# Altium schematic sheet ESSICC-CPU-PTC-DA.SchDoc(ESSICC-CPU-PTC-DA)
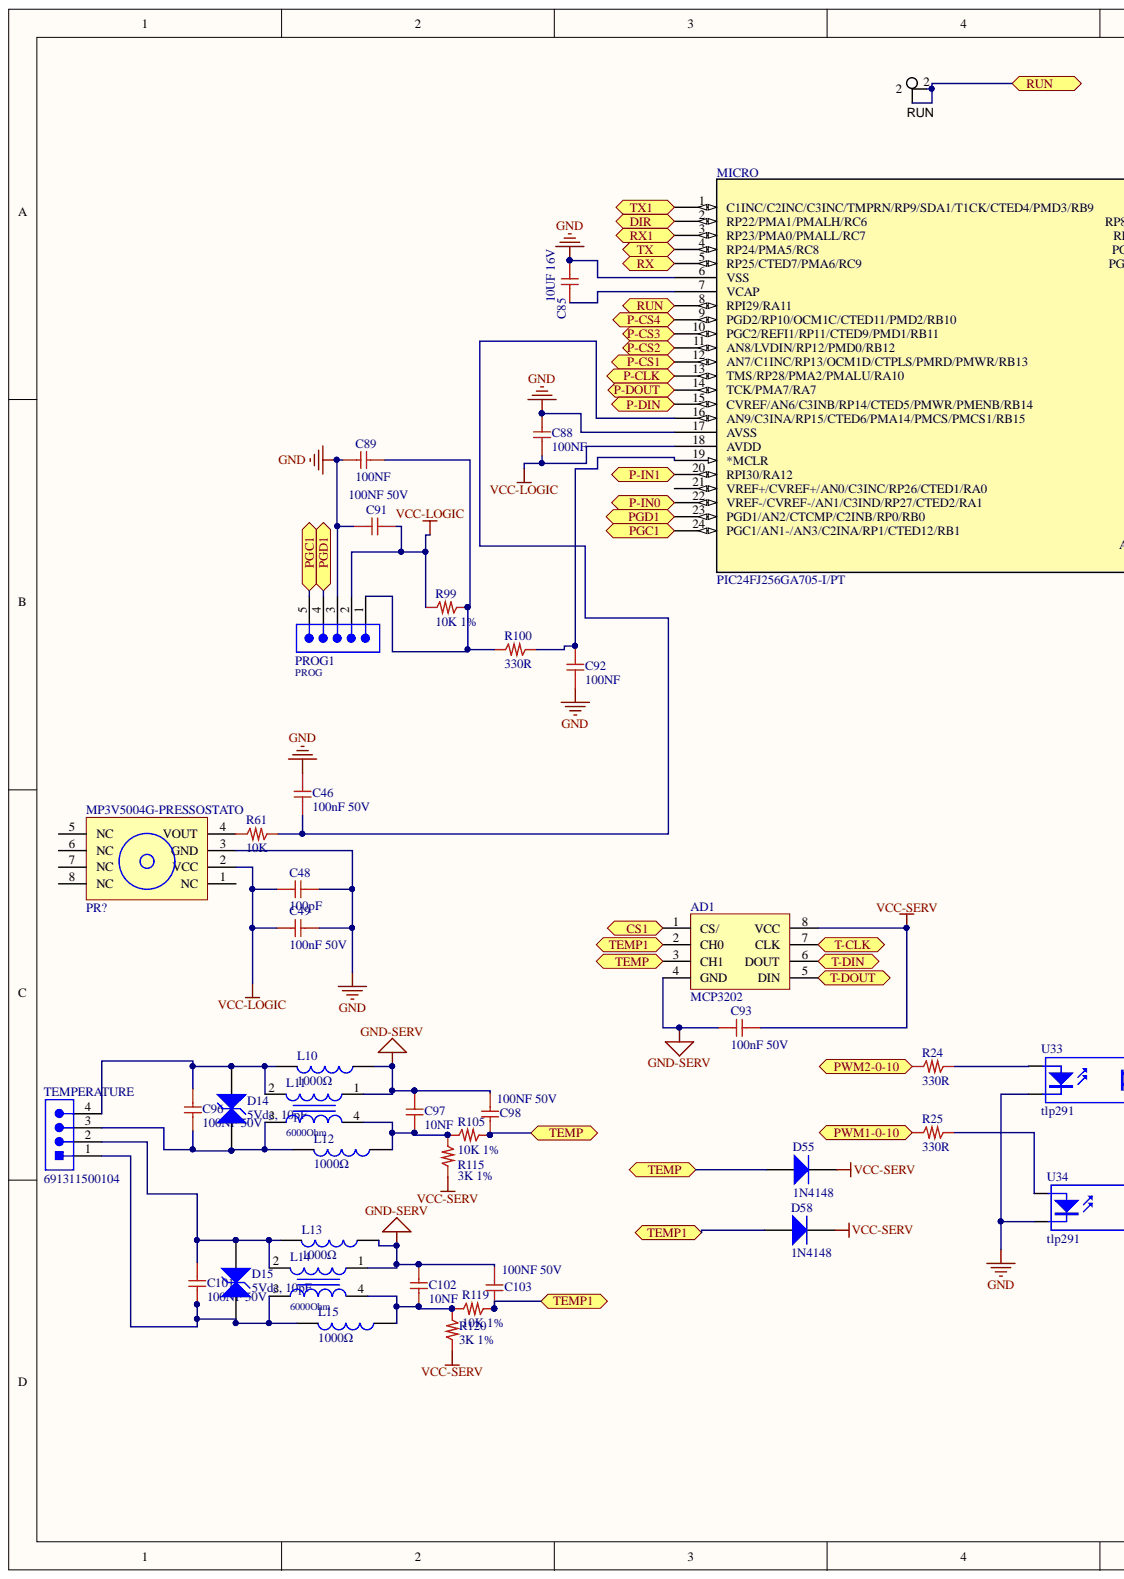
[diagram p0: ESSICC-CPU-PTC-DA.SchDoc, left]
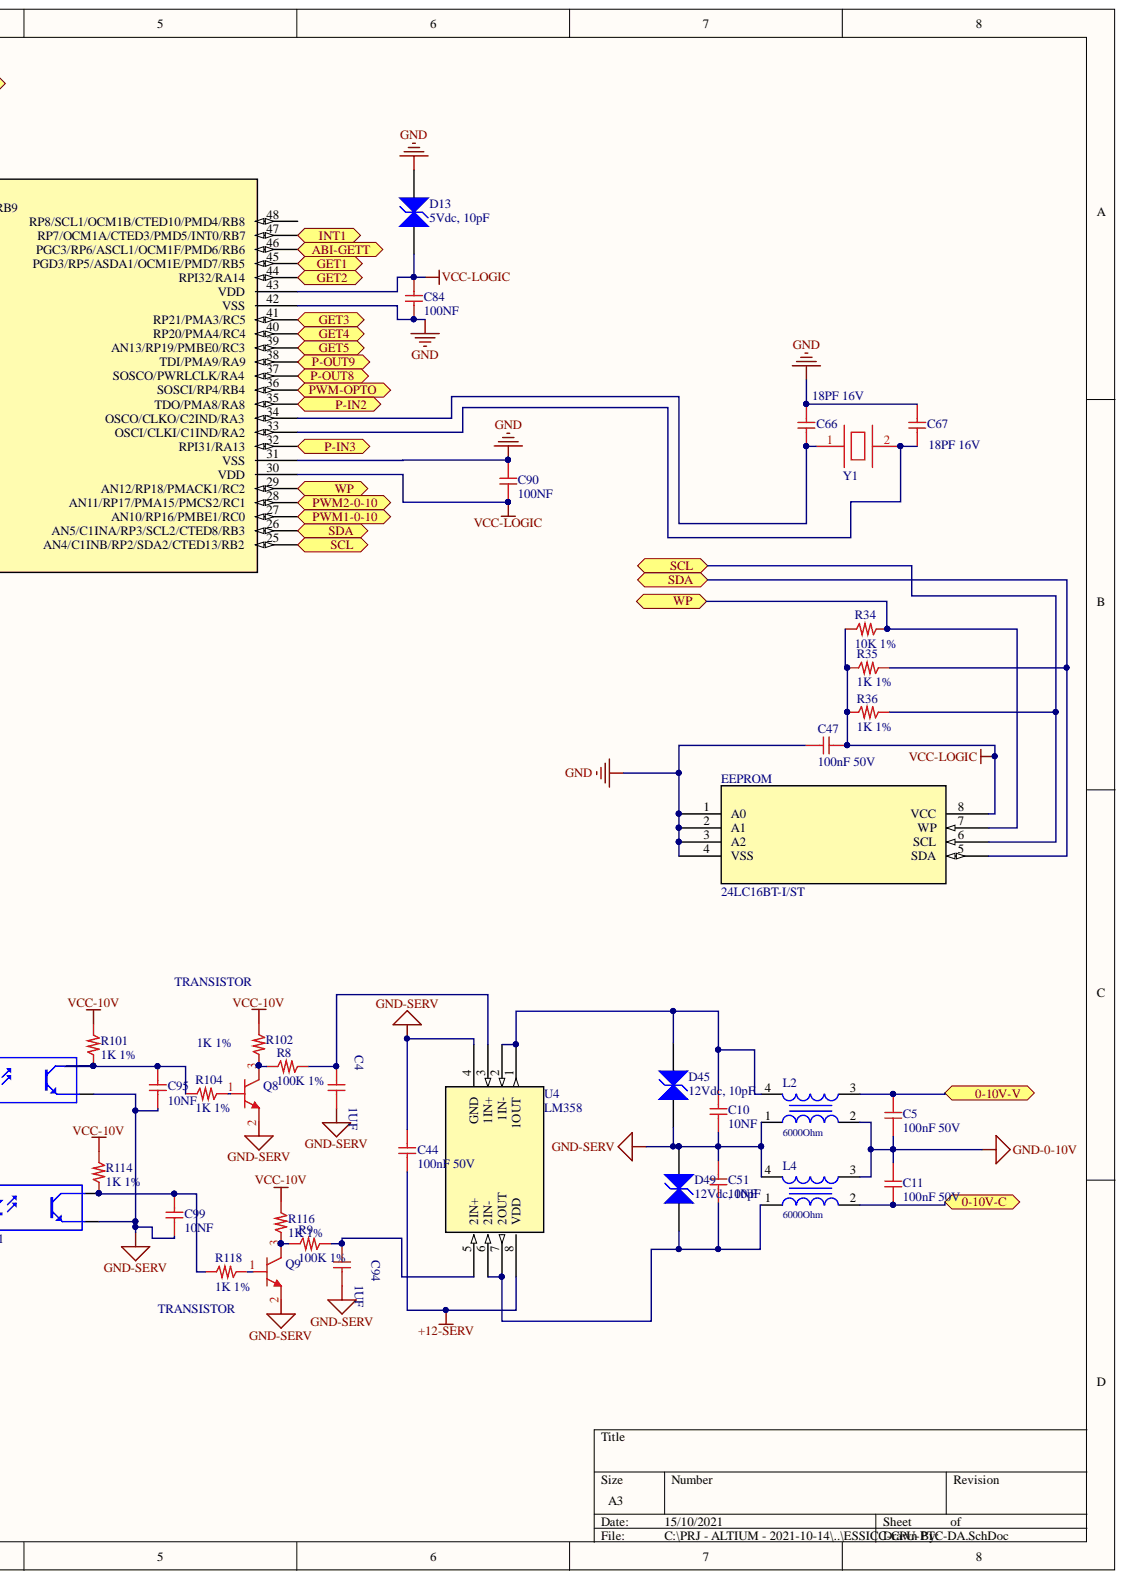
[diagram p1: ESSICC-CPU-PTC-DA.SchDoc, right]

## Parts
AD1  MCP3202  [SO-8]
C4  1UF  [0805_C]
C5  100nF 50V  [0603_c]
C10  10NF  [0402_C]
C11  100nF 50V  [0603_c]
C44  100nF 50V  [0603_c]
C46  100nF 50V  [0603_c]
C47  100nF 50V  [0603_c]
C48  100pF  [0603_c]
C49  100nF 50V  [0603_c]
C51  10NF  [0402_C]
C66  18PF 16V  [0603_c]
C67  18PF 16V  [0603_c]
C84  100NF  [0402_C]
C85  10UF 16V  [0805_C]
C88  100NF  [0402_C]
C89  100NF  [0402_C]
C90  100NF  [0402_C]
C91  100NF 50V  [0603_c]
C92  100NF  [0402_C]
C93  100nF 50V  [0603_c]
C94  1UF  [0805_C]
C95  10NF  [0402_C]
C96  100NF 50V  [0603_c]
C97  10NF  [0603_c]
C98  100NF 50V  [0603_c]
C99  10NF  [0402_C]
C101  100NF 50V  [0603_c]
C102  10NF  [0603_c]
C103  100NF 50V  [0603_c]
D13  5Vdc, 10pF  [WE-VE_0603]
D14  5Vdc, 10pF  [WE-VE_0603]
D15  5Vdc, 10pF  [WE-VE_0603]
D45  12Vdc, 10pF  [WE-VE_0603]
D49  12Vdc, 10pF  [WE-VE_0603]
D55  1N4148  [1N4148]
D58  1N4148  [1N4148]
EEPROM  24LC16BT-I/ST  [SO-8]
L2  6000Ohm  [WE-CNSW_1206]
L4  6000Ohm  [WE-CNSW_1206]
L10  1000Ω  [WE-CBF_0805_Wide Band]
L11  6000Ohm  Rated Voltage: 50V  [WE-CNSW_1206]
L12  1000Ω  [WE-CBF_0805_Wide Band]
L13  1000Ω  [WE-CBF_0805_Wide Band]
L14  6000Ohm  Rated Voltage: 50V  [WE-CNSW_1206]
L15  1000Ω  [WE-CBF_0805_Wide Band]
MICRO  PIC24FJ256GA705-I/PT  [QFP-48N]  (value not drawn)
MP3V5004G-PRESSOSTATO  PR?  [MP3V5004G]
PROG1  PROG  [PROG1 - TR]
Q8  TRANSISTOR  [SOT23]
Q9  TRANSISTOR  [SOT23]
R8  100K 1%  [0603_R]
R9  100K 1%  [0603_R]
R24  330R  [0603_R]
R25  330R  [0603_R]
R34  10K 1%  [0603_R]
R35  1K 1%  [0603_R]
R36  1K 1%  [0603_R]
R61  10K  [0603_R]
R99  10K 1%  [0603_R]
R100  330R  [0603_R]
R101  1K 1%  [0603_R]
R102  1K 1%  [0603_R]
R104  1K 1%  [0603_R]
R105  10K 1%  [0603_R]
R114  1K 1%  [0603_R]
R115  3K 1%  [0805_R]
R116  1K 1%  [0603_R]
R118  1K 1%  [0603_R]
R119  10K 1%  [0603_R]
R120  3K 1%  [0805_R]
RUN  5012  [Keystone5012]  (value not drawn)
TEMPERATURE  691311500104  [691311500104]
U4  LM358  [SO-8]
U33  tlp291  [SO4_N]
U34  tlp291  [SO4_N]
Y1  8MHZ  [QUARZO-ABM3]  (value not drawn)

## Ports
0-10V-C, 0-10V-V, ABI-GETT, CS1, DIR, GET1, GET2, GET3, GET4, GET5, INT1, P-CLK, P-CS1, P-CS2, P-CS3, P-CS4, P-DIN, P-DOUT, P-IN0, P-IN1, P-IN2, P-IN3, P-OUT8, P-OUT9, PGC1, PGD1, PWM1-0-10, PWM2-0-10, PWM-OPTO, RUN, RX, RX1, SCL, SDA, T-CLK, T-DIN, T-DOUT, TEMP, TEMP1, TX, TX1, WP

## Nets
+12-SERV: C44.2 U4.8(VDD)
GND: C46.1 C47.1 C48.2 C49.2 C66.1 C67.1 C84.2 C85.1 C88.1 C89.1 C90.1 C91.1 C92.2 D13.2 EEPROM.1(A0) EEPROM.2(A1) EEPROM.3(A2) EEPROM.4(VSS) MICRO.6(VSS) MICRO.17(AVSS) MICRO.31(VSS) MICRO.42(VSS) MP3V5004G-PRESSOSTATO.3(GND) PROG1.3 U33.2 U34.2
GND-0-10V: C5.1 C11.2 L2.2 L4.3
GND-SERV: AD1.4(GND) C4.2 C10.2 C44.1 C51.1 C93.2 C94.2 C95.2 C97.2 C98.2 C99.2 C102.2 C103.2 D45.1 D49.2 L2.1 L4.4 L10.1 L11.1 L13.1 L14.1 Q8.2 Q9.2 U4.4(GND) U33.3 U34.3
VCC-10V: R101.1 R102.1 R114.1 R116.1
VCC-LOGIC: C47.2 C48.1 C49.1 C84.1 C88.2 C90.2 C91.2 D13.1 EEPROM.8(VCC) MICRO.18(AVDD) MICRO.30(VDD) MICRO.43(VDD) MP3V5004G-PRESSOSTATO.2(VCC) PROG1.2 R34.1 R35.1 R36.1 R99.1
VCC-SERV: AD1.8(VCC) C93.1 D55.2 D58.2 R115.1 R120.1
0-10V-C: C11.1 L4.2 ; port 0-10V-C
0-10V-V: C5.2 L2.3 ; port 0-10V-V
ABI-GETT: MICRO.46(PGC3/RP6/ASCL1/OCM1F/PMD6/RB6) ; port ABI-GETT
CS1: AD1.1(CS/) ; port CS1
DIR: MICRO.2(RP22/PMA1/PMALH/RC6) ; port DIR
GET1: MICRO.45(PGD3/RP5/ASDA1/OCM1E/PMD7/RB5) ; port GET1
GET2: MICRO.44(RPI32/RA14) ; port GET2
GET3: MICRO.41(RP21/PMA3/RC5) ; port GET3
GET4: MICRO.40(RP20/PMA4/RC4) ; port GET4
GET5: MICRO.39(AN13/RP19/PMBE0/RC3) ; port GET5
INT1: MICRO.47(RP7/OCM1A/CTED3/PMD5/INT0/RB7) ; port INT1
P-CLK: MICRO.13(TMS/RP28/PMA2/PMALU/RA10) ; port P-CLK
P-CS1: MICRO.12(AN7/C1INC/RP13/OCM1D/CTPLS/PMRD/PMWR/RB13) ; port P-CS1
P-CS2: MICRO.11(AN8/LVDIN/RP12/PMD0/RB12) ; port P-CS2
P-CS3: MICRO.10(PGC2/REFI1/RP11/CTED9/PMD1/RB11) ; port P-CS3
P-CS4: MICRO.9(PGD2/RP10/OCM1C/CTED11/PMD2/RB10) ; port P-CS4
P-DIN: MICRO.15(CVREF/AN6/C3INB/RP14/CTED5/PMWR/PMENB/RB14) ; port P-DIN
P-DOUT: MICRO.14(TCK/PMA7/RA7) ; port P-DOUT
P-IN0: MICRO.22(VREF-/CVREF-/AN1/C3IND/RP27/CTED2/RA1) ; port P-IN0
P-IN1: MICRO.20(RPI30/RA12) ; port P-IN1
P-IN2: MICRO.35(TDO/PMA8/RA8) ; port P-IN2
P-IN3: MICRO.32(RPI31/RA13) ; port P-IN3
P-OUT8: MICRO.37(SOSCO/PWRLCLK/RA4) ; port P-OUT8
P-OUT9: MICRO.38(TDI/PMA9/RA9) ; port P-OUT9
PGC1: MICRO.24(PGC1/AN1-/AN3/C2INA/RP1/CTED12/RB1) PROG1.5 ; port PGC1
PGD1: MICRO.23(PGD1/AN2/CTCMP/C2INB/RP0/RB0) PROG1.4 ; port PGD1
PWM1-0-10: MICRO.27(AN10/RP16/PMBE1/RC0) R25.2 ; port PWM1-0-10
PWM2-0-10: MICRO.28(AN11/RP17/PMA15/PMCS2/RC1) R24.2 ; port PWM2-0-10
PWM-OPTO: MICRO.36(SOSCI/RP4/RB4) ; port PWM-OPTO
RUN: MICRO.8(RPI29/RA11) RUN.1 RUN.2 ; port RUN
RX: MICRO.5(RP25/CTED7/PMA6/RC9) ; port RX
RX1: MICRO.3(RP23/PMA0/PMALL/RC7) ; port RX1
SCL: EEPROM.6(SCL) MICRO.25(AN4/C1INB/RP2/SDA2/CTED13/RB2) R36.2 ; port SCL
SDA: EEPROM.5(SDA) MICRO.26(AN5/C1INA/RP3/SCL2/CTED8/RB3) R35.2 ; port SDA
T-CLK: AD1.7(CLK) ; port T-CLK
T-DIN: AD1.6(DOUT) ; port T-DIN
T-DOUT: AD1.5(DIN) ; port T-DOUT
TEMP: AD1.3(CH1) C98.1 D55.1 R105.1 ; port TEMP
TEMP1: AD1.2(CH0) C103.1 D58.1 R119.1 ; port TEMP1
TX: MICRO.4(RP24/PMA5/RC8) ; port TX
TX1: MICRO.1(C1INC/C2INC/C3INC/TMPRN/RP9/SDA1/T1CK/CTED4/PMD3/RB9) ; port TX1
WP: EEPROM.7(WP) MICRO.29(AN12/RP18/PMACK1/RC2) R34.2 ; port WP
NetC4_1: C4.1 R8.2 U4.3(1IN+)
NetC10_1: C10.1 D45.2 L2.4 U4.1(1OUT) U4.2(1IN-)
NetC46_2: C46.2 MICRO.16(AN9/C3INA/RP15/CTED6/PMA14/PMCS/PMCS1/RB15) R61.2
NetC51_2: C51.2 D49.1 L4.1 U4.6(2IN-) U4.7(2OUT)
NetC66_2: C66.2 MICRO.34(OSCO/CLKO/C2IND/RA3) Y1.1
NetC67_2: C67.2 MICRO.33(OSCI/CLKI/C1IND/RA2) Y1.2
NetC85_2: C85.2 MICRO.7(VCAP)
NetC89_2: C89.2 PROG1.1 R99.2 R100.2
NetC92_1: C92.1 MICRO.19(*MCLR) R100.1
NetC94_1: C94.1 R9.2 U4.5(2IN+)
NetC95_1: C95.1 R101.2 R104.1 U33.4
NetC96_1: C96.1 D14.1 L10.2 L11.2 TEMPERATURE.4
NetC96_2: C96.2 D14.2 L11.3 L12.2 TEMPERATURE.3
NetC97_1: C97.1 L11.4 L12.1 R105.2 R115.2
NetC99_1: C99.1 R114.2 R118.1 U34.4
NetC101_1: C101.1 D15.1 L13.2 L14.2 TEMPERATURE.2
NetC101_2: C101.2 D15.2 L14.3 L15.2 TEMPERATURE.1
NetC102_1: C102.1 L14.4 L15.1 R119.2 R120.2
NetMP3V5004G-PRESSOSTATO_4: MP3V5004G-PRESSOSTATO.4(VOUT) R61.1
NetQ8_1: Q8.1 R104.2
NetQ8_3: Q8.3 R8.1 R102.2
NetQ9_1: Q9.1 R118.2
NetQ9_3: Q9.3 R9.1 R116.2
NetR24_1: R24.1 U33.1
NetR25_1: R25.1 U34.1

Not connected: MICRO 21(VREF+/CVREF+/AN0/C3INC/RP26/CTED1/RA0) 48(RP8/SCL1/OCM1B/CTED10/PMD4/RB8); MP3V5004G-PRESSOSTATO 1(NC) 5(NC) 6(NC) 7(NC) 8(NC)

## Library data of the parts
C4 C94 = 1UF 100V: Cap X7S 1UF 100V; Produttore: TDK; Cod. Produttore: C2012X7S2A105K125AE; Cod. Interno: COCS 567061010105; Temperature Range: Da -55°C a +125°C; Library Name: CapSMD0805.SchLib; Component Kind: Standard; Cod. DigiKey: 445-8887-2-ND
C5 C11 C44 C46 C47 C49 C91 C93 C96 C98 C101 C103 = 100NF 50V: Cap X7R 100nF 50V Flex Terminal; Produttore: Samsung; Cod. Produttore: CL10B104KB8SFNC; Cod. Interno: COCS50665050104; Library Name: CapSMD0603.SchLib; Component Kind: Standard; Cod. DigiKey: 1276-1936-2-ND
C10 C51 C95 C99 = 10NF 50V: Cap X7R 10NF 50V; Produttore: ??; Cod. Interno: COCS556565000103; Library Name: CapSMD0402.SchLib; Component Kind: Standard; Cod. Produttore: ??
C48 = 100pF 50V: Cap X7R 100pF 50V; Produttore: ??; Cod. Interno: COCS??; Library Name: CapSMD0603.SchLib; Component Kind: Standard; Cod. Produttore: ??
C66 C67 = 22PF 16V: Cer X7R 22PF 16V; Cod. Interno: COCS 50665016220; Library Name: CapSMD0603.SchLib; Component Kind: Standard
C84 C88-C90 C92 = 100NF 16V: Cap X7R 100NF 16V; Produttore: ??; Cod. Interno: COCS556561600104; Library Name: CapSMD0402.SchLib; Component Kind: Standard; Cod. Produttore: ??
C85 = 10UF 16V: Cap X5R 10UF 16V; Produttore: TDK; Cod. Produttore: C2012X6S1C106K125AC; Cod. Interno: COCS517061600106; Temperature Range: Da -55°C a +105°C; Library Name: CapSMD0805.SchLib; Component Kind: Standard; Cod. DigiKey: 445-7611-2-ND
C97 C102 = 10NF 50V: Cap X7R 10nF 50V; Produttore: Samsung; Cod. Produttore: CL05B103KB5NNNC; Cod. Interno: COCS 556655000103; Library Name: CapSMD0603.SchLib; Component Kind: Standard; Cod. DigiKey: 1276-1028-2-ND
D13-D15 = 82356050100: WE-VE ESD Suppressor, size 0603, 5Vdc, 10pF; Leakage Current: 1uA; ESD Voltage air discharge: 15kV; Clamp Voltage: 60V; Working Voltage: 5Vdc; Size: 0603; Published Date: June 2019; Part Number: 82356050100; Packaging: Tape and Reel; Operating Temperature: -40 to +85degC; Mounting Technology: SMT; Library Name: WE-VE_0603.SchLib; ComponentLink2URL: https://katalog.we-online.com/pbs/datasheet/82356050100.pdf; ComponentLink2Description: Datasheet; ComponentLink1URL: http://www.we-online.de; ComponentLink1Description: Manufacturer; Component Kind: Standard; Capacitance: 10pF; Publisher: Wurth Elektronik
D45 D49 = 82356120100: WE-VE ESD Suppressor, size 0603, 12Vdc, 10pF; Leakage Current: 1uA; ESD Voltage air discharge: 15kV; Clamp Voltage: 60V; Working Voltage: 12Vdc; Size: 0603; Published Date: June 2019; Part Number: 82356120100; Packaging: Tape and Reel; Operating Temperature: -40 to +85degC; Mounting Technology: SMT; Library Name: WE-VE_0603.SchLib; ComponentLink2URL: https://katalog.we-online.com/pbs/datasheet/82356120100.pdf; ComponentLink2Description: Datasheet; ComponentLink1URL: http://www.we-online.de; ComponentLink1Description: Manufacturer; Component Kind: Standard; Capacitance: 10pF; Publisher: Wurth Elektronik
D55 D58 = 1N4148: High Conductance Fast Diode; Published: 8-Jun-2000; PackageReference: DO-35; PackageDescription: Diode, Axial; Body 3.8 x 1.9 mm (LxDia. max), Lead Dia. 0.53 mm (max); LatestRevisionNote: Re-released for DXP Platform.; LatestRevisionDate: 17-Jul-2002; DatasheetVersion: 1997; ComponentLink2URL: http://www.fairchildsemi.com/ds/1N%2F1N4148.pdf; ComponentLink2Description: Datasheet; ComponentLink1URL: http://www.fairchildsemi.com/; ComponentLink1Description: Manufacturer Link; Component Kind: Standard; Code_JEDEC: DO-204-AH; Publisher: Altium Limited; Library Name: HSW.SchLib
EEPROM = 24LC16BT-I/ST: 16K I2C Serial EEPROM; Manufacturer_Part_Number: 24LC512T-I/ST; Vendor: Microchip; Built_By: EMA_UL_Team; Value: Value; Type: DEV; RefDes: RefDes; Component Kind: Standard; Library Name: HSW.SchLib; Copyright: Copyright (C) 2018 Accelerated Designs. All rights reserved
L2 L4 L11 L14 = 744232101: WE-CNSW SMT Common Mode Line Filter, size 1206, 6000Ohm, 0.09A, 50V; Manufacturer: Wurth Elektronik; Winding Style: bifiliar; Insulation Test Voltage: 125VAC; Impedance Test Condition: 100MHz; DC Resistance max.: 5.5Ohm; Size: 1206; Rated Current: 0.09A; Published Date: April 2020; Packaging: Tape and Reel; Operating Temperature: -40 to +125degC; Mounting Technology: SMT; Manufacturer Part Number: 744232101; Library Name: WE-CNSW_1206.SchLib; Impedance: 6000Ohm; ComponentLink2URL: https://www.we-online.com/catalog/datasheet/744232101.pdf; ComponentLink2Description: Datasheet; ComponentLink1URL: http://www.we-online.de; ComponentLink1Description: Manufacturer; Component Kind: Standard
  L2 L4: Rated Voltage: 50V
L10 L12 L13 L15 = WE-CBF, 74279205: WE-CBF SMD EMI Suppression Ferrite Bead, 0805, 1000Ω; Type: Wide Band; Value: 1000Ω; Size: 0805; PublishedDate: Aug 2016; PartNumber: 74279205; Library Name: WE-CBF_0805.SchLib; ComponentLink2URL: http://katalog.we-online.de/pbs/datasheet/74279205.pdf; ComponentLink2Description: Datasheet; ComponentLink1URL: http://www.we-online.de; ComponentLink1Description: Manufacturer; Component Kind: Standard; Publisher: Wurth Elektronik
MICRO = PIC24FJ256GA705-I/PT: 16-Bit General Purpose Microcontrollers with 256-Kbyte Flash and 16-Kbyte RAM in Low Pin Count Packages; Manufacturer_Part_Number: PIC24FJ256GA705-I/M4; Vendor: Microchip; SourceLibrary: Add_to_database_2020-11-20; Built_By: EMA_Cory; Type: DEV; RefDes: RefDes; Datasheet: https://ww1.microchip.com/downloads/en/DeviceDoc/PIC24FJ256GA705-Family-Data-Sheet-DS30010118E.pdf; Component Kind: Standard; Library Name: HSW.SchLib; Copyright: Copyright (C) 2018 Accelerated Designs. All rights reserved
MP3V5004G-PRESSOSTATO = MP3V5004G: Component Kind: Standard; Library Name: HSW.SchLib
PROG1 = PROG1-TR: SMT Vertical Pin Header WR-PHD, Pitch 2.54 mm, Single Row, 6 pins; Part Number: 61000618321; Working Voltage: 250 VAC; Withstanding Voltage: 500 VAC; Rated Current: 3 A; Pitch: 2.54 mm; Pin Number: 6; Gender: Male; Contact Resistance: 20 mOhm; Row Number: Single; Published Date: August 2018; Packaging: Tube; Orientation: Vertical; Operating Temperature: -40 to 125 degC; Mounting Technology: SMT; ComponentLink2URL: https://katalog.we-online.de/em/datasheet/6100xx18321.pdf; ComponentLink2Description: Datasheet; ComponentLink1URL: http://www.we-online.de; ComponentLink1Description: Manufacturer; Component Kind: Standard; Publisher: Wurth Elektronik; Library Name: HSW.SchLib
Q8 Q9 = BC847B: NPN Silicon Darlington Transistors; Vce: 30V; Vcb: 40V; Produttore: INFINEON; Ic: 500mA; Cod. Produttore: BCV27; Cod. Interno: TRXS ??; Component Kind: Standard; Library Name: HSW.SchLib
R8 R9 = 100K 1%: Res Film Spesso 1/16W 100K 1%; Produttore: ??; Cod. Interno: RESS4166360C0104; Library Name: ResSMD0603.SchLib; Component Kind: Standard; Cod. Produttore: ??
R24 R25 R100 = 330R: Res Film Spesso 1/16W 330R 5%; Cod. Interno: RESS4166556B0331; Library Name: ResSMD0603.SchLib; Component Kind: Standard
R34 R99 R105 R119 = 10K 1%: Res Film Spesso 1/16W 10K 1%; Produttore: Panasonic; Cod. Produttore: ERJ-3EKF1002V; Cod. Interno: RESS4166356B0103; Library Name: ResSMD0603.SchLib; Component Kind: Standard
R35 R36 R101 R102 R104 R114 R116 R118 = 1K 1%: Res Film Spesso 1/16W 1K 1%; Produttore: ??; Cod. Interno: RESS4166356B0102; Library Name: ResSMD0603.SchLib; Component Kind: Standard; Cod. Produttore: ??
R61 = 2K7: Res Film Spesso 1/16W 2K7 5%; Produttore: VISHAY; Cod. Produttore: CRCW06032K70JNEA; Cod. Interno: RESS4166556B0272; Library Name: ResSMD0603.SchLib; Component Kind: Standard
R115 R120 = 3K 1%: Res Film Spesso 0805 1/2W 3K 1%; Cod. Interno: ??; Library Name: ResSMD0805.SchLib; Component Kind: Standard
RUN = 5012_1: Test Point, Multipurpose, White, TH; Manufacturer: Keystone; ContactFinish: Silver; CompType: Test Point Loop; SymbolVersion: 2008-04-04; Supplier Part Number 2: 534-5012; Supplier Part Number 1: 36-5012-ND; Supplier 2: Mouser; Supplier 1: Digi-Key; RoHS: Y; PartNumber: 5012; PackageReference: White Multipurpose Testpoint; MountType: TH; LatestRevisionDate: 2017-01-19; ComponentLink1URL: http://www.keyelco.com/userAssets/file/M60-2p60.pdf; ComponentLink1Description: Datasheet URL; Component Kind: Standard; Color: White; Publisher: Texas Instruments; Library Name: HSW.SchLib
TEMPERATURE = 691311500104: WR-TBL Serie 311 Close Vertical PCB Header, Pluggable, pitch 5.08mm, 4p; Working Voltage [UL]: 300VAC; Rated Current [UL]: 20A; Pin Number: 4; Modularity: No; Compliance: ROHS & Reach; Type: Vertical; Published Date: October 2019; Pitch: 5.08mm; PCB/Cable/Panel: PCB; Part Number: 691311500104; Packaging: Box; Operating Temperature: -40 to +105degC; Mounting Technology: THT; Library Name: WR-TBL_Pluggable PCB Header.SchLib; ComponentLink2URL: https://katalog.we-online.de/em/datasheet/691311500104.pdf; ComponentLink2Description: Datasheet; ComponentLink1URL: http://www.we-online.de; ComponentLink1Description: Manufacturer; Component Kind: Standard; Application: Pluggable; Publisher: Wurth Elektronik
U4 = LM358: Industry-Standard Dual Operational Amplifiers; Manufacturer_Part_Number: TLC272CDR; Vendor: Texas Instruments; Built_By: EMA_UL_Team; Value: Value; Type: DEV; RefDes: RefDes; Component Kind: Standard; Library Name: HSW.SchLib; Copyright: Copyright (C) 2018 Accelerated Designs. All rights reserved
U33 U34 = tlp291: 4-Pin Phototransistor Optocoupler; Published: 24-Mar-1999; PackageReference: DIP-4; LatestRevisionNote: Sch pins 3 & 4 Swapped.; LatestRevisionDate: 04-Mar-2005; DatasheetVersion: Nov-2003; Component Kind: Standard; Publisher: Altium Limited; Library Name: HSW.SchLib
Y1 = QUARZO-ABM3-8MHZ: QUARZO SMD 8MHZ ABM3; Produttore: TXC; Costo 1000: 0.301; Costo 100: 0.326; Cod. Produttore: 9HT10-32.768KDZB-T; Cod. Interno: ??; Component Kind: Standard; Cod. Farnell: 2819301; Library Name: HSW.SchLib
AD1 = MCP3202: Component Kind: Standard; Library Name: HSW.SchLib
